# Altium schematic sheet 100_RF.SchDoc
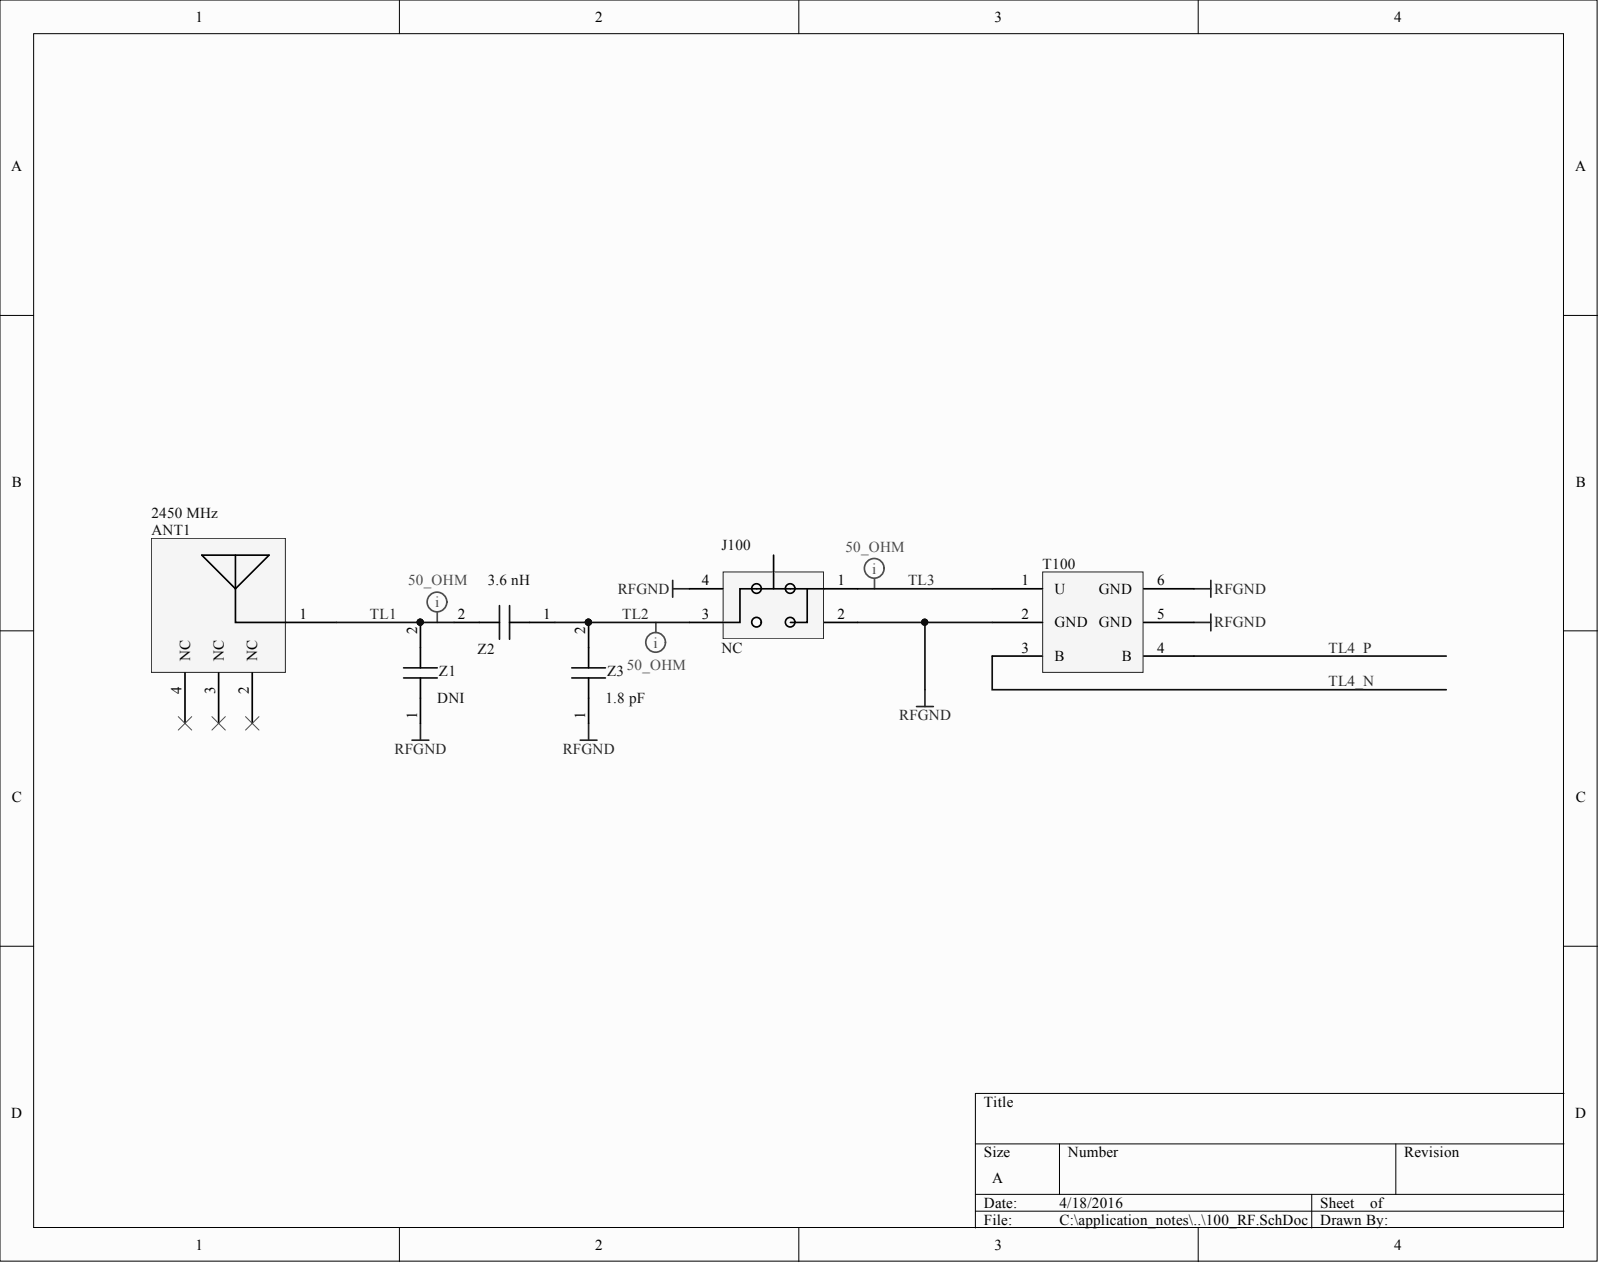
[diagram p0: 100_RF.SchDoc]

## Parts
ANT1  2450 MHz  [2540AT42B100]
J100  NC  [MM8030-2610]
T100  50 Ohm  [MURATA_LFL212]  (value not drawn)
Z1  DNI  [CAPC1005N]
Z2  3.6 nH  [CAPC1005N]
Z3  1.8 pF  [CAPC1005N]

## Nets
RFGND: J100.2 J100.4 T100.2(GND) T100.5(GND) T100.6(GND) Z1.1 Z3.1
TL1: ANT1.1 Z1.2 Z2.2
TL2: J100.3 Z2.1 Z3.2
TL3: J100.1 T100.1(U)
TL4_N: T100.3(B)
TL4_P: T100.4(B)

Not connected: ANT1 2(NC) 3(NC) 4(NC)

## Library data of the parts
ANT1 = 2450AT42B100: ANTENNA CHIP 2.4GHZ; MPN: 2450AT42B100E; MFG: Johanson; VENDOR: Digikey; VPN: 712-1008-1-ND; Component Kind: Standard; Library Name: 2450AT42B100.SchLib; VALUE: 2450 MHz
J100 = MM8030-2610: CONN SWG JACK STR 50 OHM SMD; MPN: MM8030-2610RJ3; MFG: Murata; Vendor: Digikey; VPN: 490-5907-1-ND; Component Kind: Standard; Library Name: mm8030-2610.SchLib; Value: NC
T100 = LFL212G45TG1D569: Balun, Conjugated match to AT86RF23x; MFG: Murata; VPN: CONSIGNMENT; Component Kind: Standard; MPN: LFL212G45TG1D569; Value: 50 Ohm; Vendor: Murata; Library Name: baluns.SchLib
Z1 = C_0402: C 0402; MFG: GENERIC; Component Kind: Standard; Library Name: rlc.SchLib; VALUE: DNI
Z2 = C_0402: C 0402; MPN: LQG15HS3N6S02D; MFG: MURATA; VENDOR: Digikey; VPN: 490-6571-1-ND; Component Kind: Standard; Library Name: rlc.SchLib; VALUE: 3.6 nH
Z3 = C_0402: C 0402; MPN: GJM1555C1H1R8CB01D; MFG: MURATA; VENDOR: Digikey; VPN: 490-3089-1-ND; Component Kind: Standard; Library Name: rlc.SchLib; VALUE: 1.8 pF
